# Altium schematic sheet PowerSupply.SchDoc
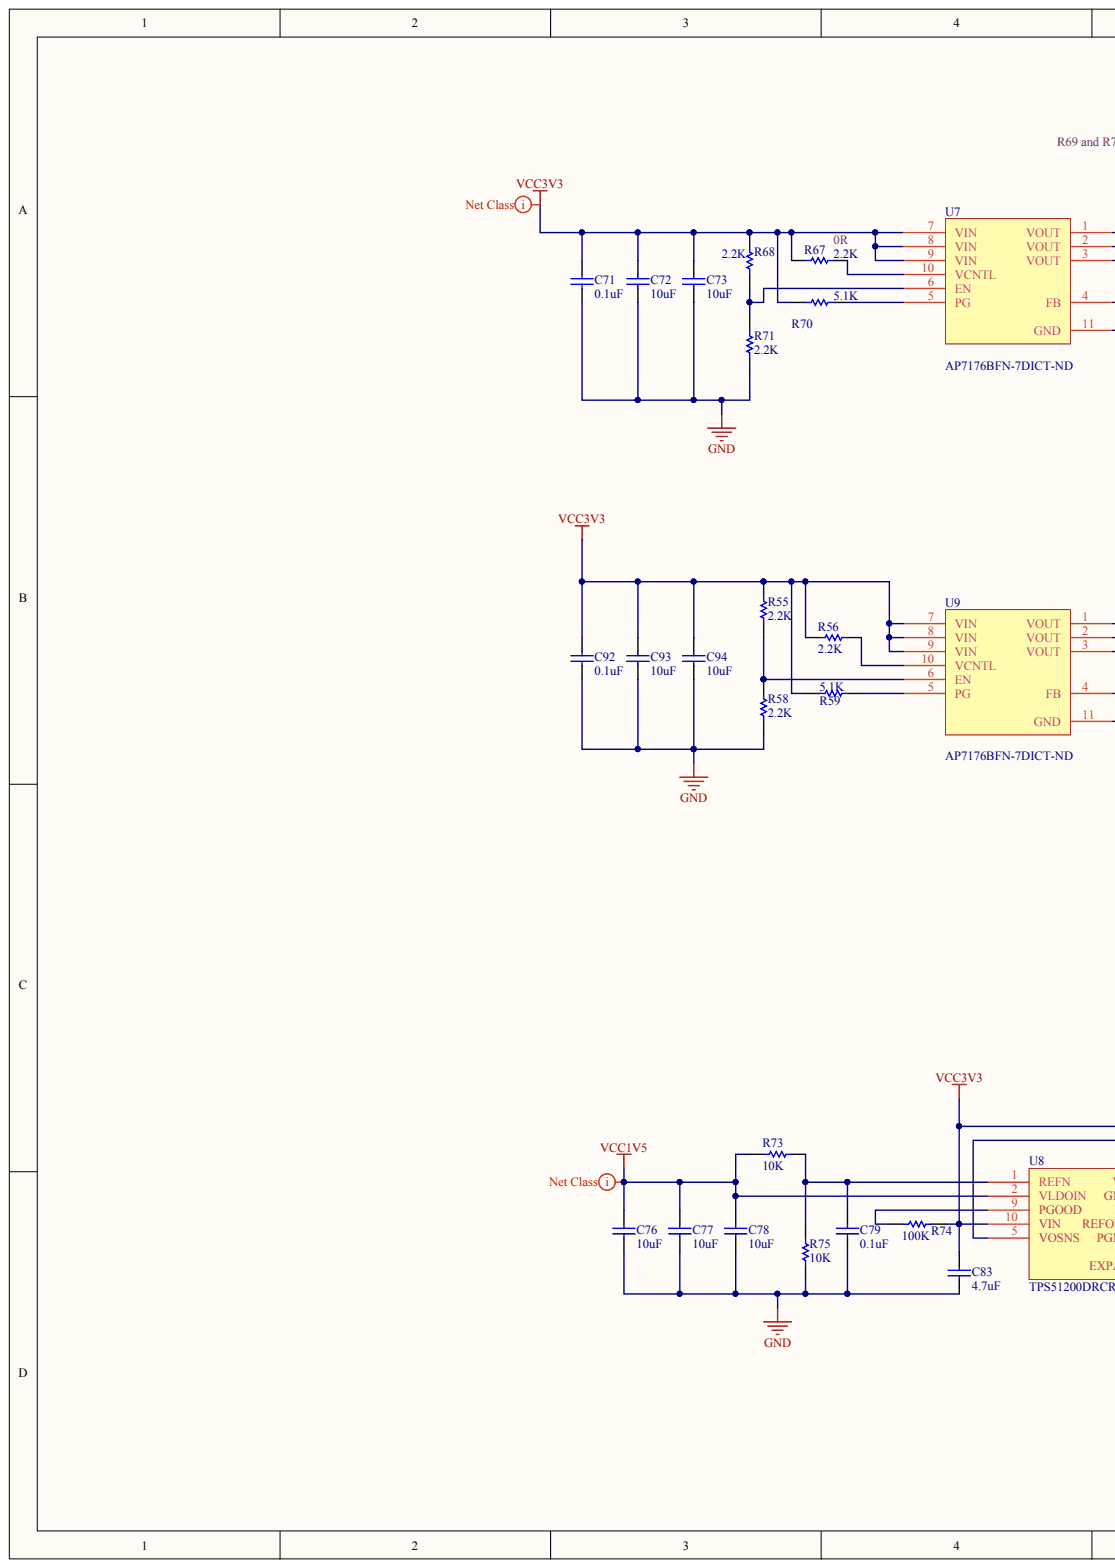
[diagram p0: PowerSupply.SchDoc, left]
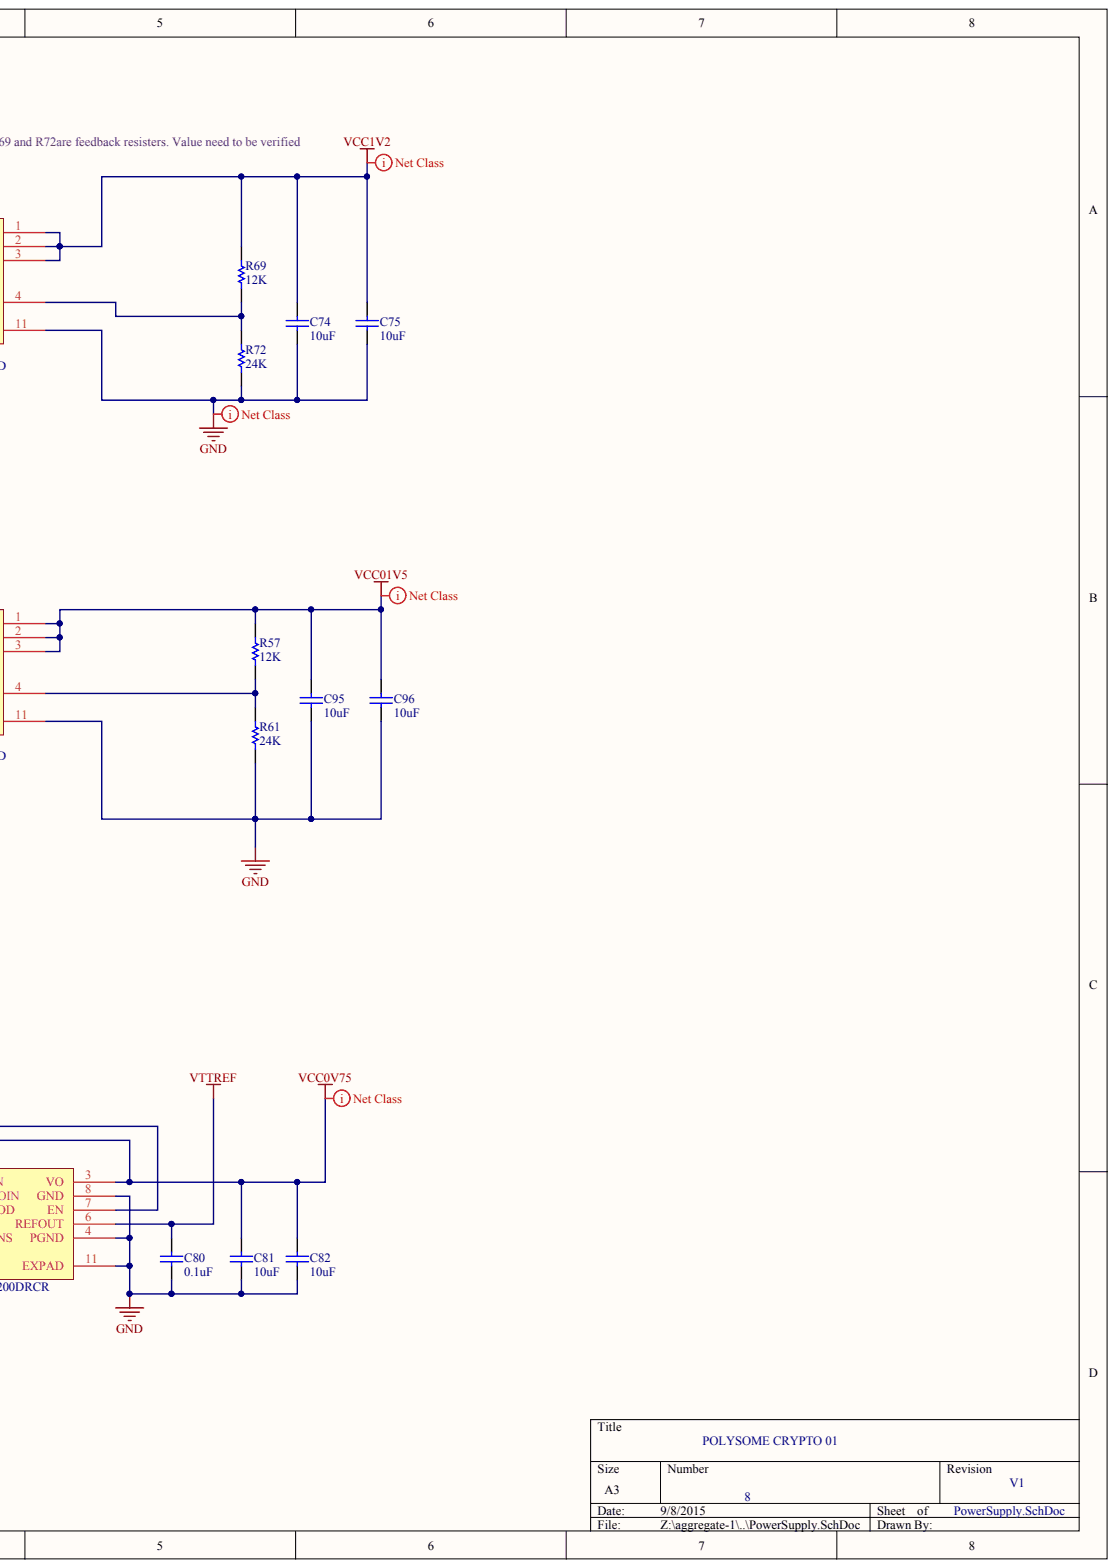
[diagram p1: PowerSupply.SchDoc, right]

## Parts
C71  value 0.1uF  [0402]  (hidden comment: Cap Semi)
C72  value 10uF  [1608[0603]]  (hidden comment: Cap Semi)
C73  value 10uF  [1608[0603]]  (hidden comment: Cap Semi)
C74  value 10uF  [C0805]  (hidden comment: Cap Semi)
C75  value 10uF  [C0805]  (hidden comment: Cap Semi)
C76  value 10uF  [1608[0603]]  (hidden comment: Cap Semi)
C77  value 10uF  [1608[0603]]  (hidden comment: Cap Semi)
C78  value 10uF  [0402]  (hidden comment: Cap Semi)
C79  value 0.1uF  [0402]  (hidden comment: Cap Semi)
C80  value 0.1uF  [0402]  (hidden comment: Cap Semi)
C81  value 10uF  [0402]  (hidden comment: Cap Semi)
C82  value 10uF  [C0805]  (hidden comment: Cap Semi)
C83  value 4.7uF  [0402]  (hidden comment: Cap Semi)
C92  value 0.1uF  [0402]  (hidden comment: Cap)
C93  value 10uF  [1608[0603]]  (hidden comment: Cap)
C94  value 10uF  [1608[0603]]  (hidden comment: Cap)
C95  value 10uF  [1608[0603]]  (hidden comment: Cap)
C96  value 10uF  [1608[0603]]  (hidden comment: Cap)
R55  value 2.2K  [0402]  (hidden comment: Res Semi)
R56  value 2.2K  [0402]  (hidden comment: Res Semi)
R57  value 12K  [0402]  (hidden comment: Res Semi)
R58  value 2.2K  [0402]  (hidden comment: Res Semi)
R59  value 5.1K  [0402]  (hidden comment: Res Semi)
R61  value 24K  [0402]  (hidden comment: Res Semi)
R67  value 2.2K  [0402]  (hidden comment: Res Semi)
R68  value 2.2K  [0402]  (hidden comment: Res Semi)
R69  value 12K  [0402]  (hidden comment: Res Semi)
R70  value 5.1K  [0402]  (hidden comment: Res Semi)
R71  value 2.2K  [0402]  (hidden comment: Res Semi)
R72  value 24K  [0402]  (hidden comment: Res Semi)
R73  value 10K  [0402]  (hidden comment: Res Semi)
R74  value 100K  [0402]  (hidden comment: Res Semi)
R75  value 10K  [0402]  (hidden comment: Res Semi)
U7  AP7176BFN-7DICT-ND  [PSON50P300X100_HS-11N]
U8  TPS51200DRCR  [PSON50P300X100_HS-11N]
U9  AP7176BFN-7DICT-ND  [PSON50P300X100_HS-11N]

## Nets
GND: C71.2 C72.2 C73.2 C74.2 C75.2 C76.2 C77.2 C78.2 C79.2 C80.2 C81.2 C82.2 C83.2 C92.1 C93.1 C94.1 C95.1 C96.1 R58.1 R61.1 R71.1 R72.1 R75.1 U7.11(GND) U8.4(PGND) U8.8(GND) U8.11(EXPAD) U9.11(GND)
VCC0V75: C81.1 C82.1 U8.3(VO) U8.5(VOSNS)
VCC1V2: C74.1 C75.1 R69.2 U7.1(VOUT) U7.2(VOUT) U7.3(VOUT)
VCC01V5: C95.2 C96.2 R57.2 U9.1(VOUT) U9.2(VOUT) U9.3(VOUT)
VCC1V5: C76.1 C77.1 C78.1 R73.1 U8.2(VLDOIN)
VCC3V3: C71.1 C72.1 C73.1 C83.1 C92.2 C93.2 C94.2 R55.2 R56.2 R59.2 R67.1 R68.2 R70.1 R74.2 U7.7(VIN) U7.8(VIN) U7.9(VIN) U8.7(EN) U8.10(VIN) U9.7(VIN) U9.8(VIN) U9.9(VIN)
VTTREF: C80.1 U8.6(REFOUT)
NetC79_1: C79.1 R73.2 R75.2 U8.1(REFN)
NetR55_1: R55.1 R58.2 U9.6(EN)
NetR56_1: R56.1 U9.10(VCNTL)
NetR57_1: R57.1 R61.2 U9.4(FB)
NetR59_1: R59.1 U9.5(PG)
NetR67_2: R67.2 U7.10(VCNTL)
NetR68_1: R68.1 R71.2 U7.6(EN)
NetR69_1: R69.1 R72.2 U7.4(FB)
NetR70_2: R70.2 U7.5(PG)
NetR74_1: R74.1 U8.9(PGOOD)

## Library data of the parts
C71-C83 = Cap Semi: Capacitor (Semiconductor SIM Model); Component Kind: Standard; LatestRevisionDate: 29-May-2009; LatestRevisionNote: IPC-7351 Footprint Added.; Library Name: Miscellaneous Devices.IntLib; PackageDescription: Chip Capacitor; PackageReference: C1206; Published: 8-Jun-2000; Signal Integrity: Cap Semi; Simulation: CAP; Publisher: Altium Limited
C92-C96 = Cap: Capacitor; Component Kind: Standard; LatestRevisionDate: 17-Jul-2002; LatestRevisionNote: Re-released for DXP Platform.; Library Name: Miscellaneous Devices.IntLib; PackageDescription: Radial Cap, Thru-Hole; 2 Leads; 0.3 in Pin Spacing; PackageReference: RAD-0.3; Published: 8-Jun-2000; Signal Integrity: Cap; Simulation: CAP; Publisher: Altium Limited
R55-R59 R61 R67-R75 = Res Semi: Semiconductor Resistor; Component Kind: Standard; LatestRevisionDate: 17-Jul-2002; LatestRevisionNote: Re-released for DXP Platform.; Library Name: Miscellaneous Devices.IntLib; PackageDescription: Axial Device, Thru-Hole; 2 Leads; 0.5 in Pin Spacing; PackageReference: AXIAL-0.5; Published: 8-Jun-2000; Signal Integrity: Res Semi; Simulation: RES; Publisher: Altium Limited
U7 U9 = AP7176BFN-7: IC REG LDO ADJ 3A 10-DFN; Manufacturer: Diodes Incorporated; Current - Limit (Min): 4.5A; Number of Regulators: 1; Regulator Topology: Positive Adjustable; Voltage - Dropout (Typical): 0.33V @ 3A; Voltage - Input: 1.2 V ~ 3.65 V; Voltage - Output: 0.8 V ~ 5.17 V; Category: Integrated Circuits (ICs); Component Kind: Standard; ComponentLink1Description: http://diodes.com/datasheets/AP7176B.pdf; ComponentLink1URL: http://diodes.com/datasheets/AP7176B.pdf; Current - Output: 3A; Manufacturer Part Number: AP7176BFN-7; Mounting Type: Surface Mount; Operating Temperature: -40°C ~ 85°C; Package / Case: 10-UFDFN Exposed Pad; Packaging: Cut Tape (CT); Pricing 1: 1=0.99, 10=0.877, 25=0.792, 100=0.693, 250=0.60776, 500=0.539, 1000=0.42625 (USD); RoHS: RoHS Compliant; Stock 1: 8535; Supplier 1: Digi-Key; Supplier Device Package: 10-UDFN (3x3); Supplier Part Number 1: AP7176BFN-7DICT-ND; Library Name: PolySomeCrypto01.SchLib
U8 = TPS51200DRCR: IC CONV DDR DDR2 DDR3 10SON; Manufacturer: Texas Instruments; Number of Outputs: 1; Voltage - Input: 2.38 V ~ 3.5 V; Applications: Converter, DDR; Category: Integrated Circuits (ICs); Component Kind: Standard; ComponentLink1Description: http://www.ti.com/lit/ds/symlink/tps51200.pdf; ComponentLink1URL: http://www.ti.com/lit/ds/symlink/tps51200.pdf; Manufacturer Part Number: TPS51200DRCR; Mounting Type: Surface Mount; Operating Temperature: -40°C ~ 85°C; Package / Case: 10-VFDFN Exposed Pad; Packaging: Cut Tape (CT); Pricing 1: 1=2.15, 10=1.944, 25=1.7416, 100=1.566, 250=1.39052, 500=1.215, 750=1.10025, 1000=0.84375 (USD); RoHS: RoHS Compliant; Stock 1: 49935; Supplier 1: Digi-Key; Supplier Device Package: 10-VSON (3x3); Supplier Part Number 1: 296-26978-1-ND; Voltage - Output: -; Library Name: PolySomeCrypto01.SchLib
